# Altium schematic sheet CPU.SchDoc
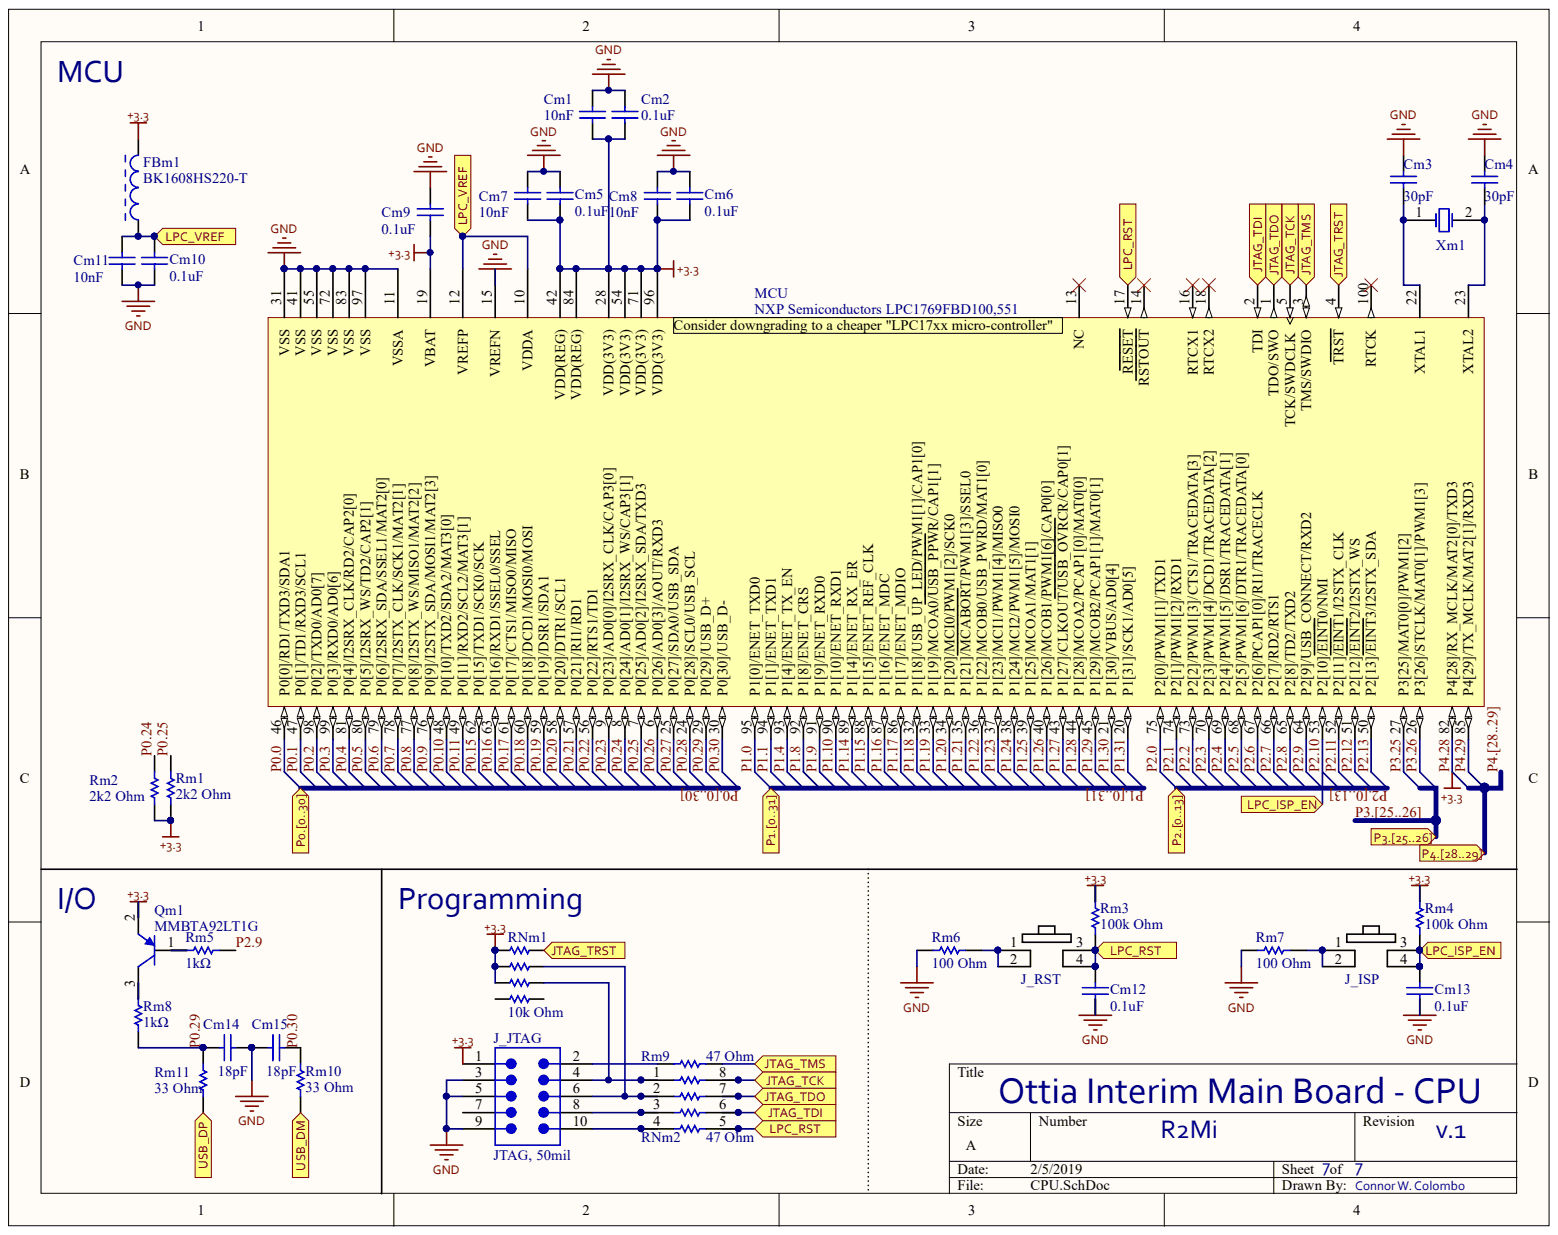
[diagram p0: CPU.SchDoc]

## Parts
Cm1  10nF  [C0402_B]
Cm2  0.1uF  [PCB-eedgklwdvp799dppbzpc-1]
Cm3  30pF  [PCB-8eq59f20arps2odoxr5p-1]
Cm4  30pF  [PCB-8eq59f20arps2odoxr5p-1]
Cm5  0.1uF  [PCB-eedgklwdvp799dppbzpc-1]
Cm6  0.1uF  [PCB-eedgklwdvp799dppbzpc-1]
Cm7  10nF  [C0402_B]
Cm8  10nF  [C0402_B]
Cm9  0.1uF  [PCB-eedgklwdvp799dppbzpc-1]
Cm10  0.1uF  [PCB-eedgklwdvp799dppbzpc-1]
Cm11  10nF  [C0402_B]
Cm12  0.1uF  [PCB-eedgklwdvp799dppbzpc-1]
Cm13  0.1uF  [PCB-eedgklwdvp799dppbzpc-1]
Cm14  18pF  [CAPC2013X88X50LL20T25]
Cm15  18pF  [CAPC2013X88X50LL20T25]
FBm1  BK1608HS220-T  [PCB-bv179vn443xkzl6mx6vj-1]
J_ISP  SYM-62e9a967b90dbd2e-1  [PCB-gaic1pl4705ifv3nefaq-1]  (value not drawn)
J_JTAG  JTAG, 50mil  [PCB-sv1um6pcy0j9gku5nhxe-1]
J_RST  SYM-62e9a967b90dbd2e-1  [PCB-gaic1pl4705ifv3nefaq-1]  (value not drawn)
MCU  NXP Semiconductors LPC1769FBD100,551  Manufacturer Part Number: LPC1769FBD100,551  [PCB-mc62e6spmbpfzkbif15a-1]
Qm1  MMBTA92LT1G  [ONSC-SOT-23-3-318-08_V]
Rm1  2k2 Ohm  [PCB-z7doepf12wtckttpzfig-1]
Rm2  2k2 Ohm  [PCB-z7doepf12wtckttpzfig-1]
Rm3  100k Ohm  [RESC1005X03L]
Rm4  100k Ohm  [RESC1005X03L]
Rm5  1kΩ  [PCB-prt6fc5zwjad3b6bgvrp-1]
Rm6  100 Ohm  [RESC1608X06N]
Rm7  100 Ohm  [RESC1608X06N]
Rm8  1kΩ  [PCB-prt6fc5zwjad3b6bgvrp-1]
Rm9  47 Ohm  [RESC1005X40X25LL05T10]
Rm10  33 Ohm  [PCB-9zfrujlo8x73edb5pce5-1]
Rm11  33 Ohm  [PCB-9zfrujlo8x73edb5pce5-1]
RNm1  10k Ohm  [PCB-jvpnorgmdmak109y2eet-1]
RNm2  47 Ohm  [PCB-wrffq4r8to75u59gxph1-1]
Xm1  Abracon ABLS-12.000MHZ-B2-T  [PCB-17bxwujfmayt9s0k9qiq-1]  (value not drawn)

## Ports
JTAG_TCK, JTAG_TDI, JTAG_TDO, JTAG_TMS, JTAG_TRST, LPC_ISP_EN, LPC_RST, LPC_VREF, P0.0, P0.1, P0.2, P0.3, P0.4, P0.5, P0.6, P0.7, P0.8, P0.9, P0.10, P0.11, P0.12, P0.13, P0.14, P0.15, P0.16, P0.17, P0.18, P0.19, P0.20, P0.21, P0.22, P0.23, P0.24, P0.25, P0.26, P0.27, P0.28, P0.29, P0.30, P0.[0..30], P1.0, P1.1, P1.2, P1.3, P1.4, P1.5, P1.6, P1.7, P1.8, P1.9, P1.10, P1.11, P1.12, P1.13, P1.14, P1.15, P1.16, P1.17, P1.18, P1.19, P1.20, P1.21, P1.22, P1.23, P1.24, P1.25, P1.26, P1.27, P1.28, P1.29, P1.30, P1.31, P1.[0..31], P2.0, P2.1, P2.2, P2.3, P2.4, P2.5, P2.6, P2.7, P2.8, P2.9, P2.10, P2.11, P2.12, P2.13, P2.[0..13], P3.25, P3.26, P3.[25..26], P4.28, P4.29, P4.[28..29], USB_DM, USB_DP

## Nets
GND: Cm1.1 Cm2.2 Cm3.2 Cm4.2 Cm5.2 Cm6.2 Cm7.1 Cm8.1 Cm9.2 Cm10.1 Cm11.2 Cm12.1 Cm13.1 Cm14.1 Cm15.2 J_JTAG.3 J_JTAG.5 J_JTAG.9 MCU.11(VSSA) MCU.15(VREFN) MCU.31(VSS) MCU.41(VSS) MCU.55(VSS) MCU.72(VSS) MCU.83(VSS) MCU.97(VSS) Rm6.1 Rm7.1
JTAG_TCK: MCU.5(TCK/SWDCLK) RNm2.8 ; port JTAG_TCK
JTAG_TDI: MCU.2(TDI) RNm2.6 ; port JTAG_TDI
JTAG_TDO: MCU.1(TDO/SWO) RNm2.7 ; port JTAG_TDO
JTAG_TMS: MCU.3(TMS/SWDIO) Rm9.2 ; port JTAG_TMS
JTAG_TRST: MCU.4(TRST) RNm1.4 ; port JTAG_TRST
LPC_RST: Cm12.2 J_RST.3 J_RST.4 MCU.17(RESET) Rm3.1 RNm2.5 ; port LPC_RST
LPC_VREF: Cm10.2 Cm11.1 FBm1.1 MCU.10(VDDA) MCU.12(VREFP) ; port LPC_VREF
P0.0: MCU.46(P0[0]/RD1/TXD3/SDA1) ; port P0.[0..30]  [also labelled P0.]
P0.1: MCU.47(P0[1]/TD1/RXD3/SCL1) ; port P0.[0..30]  [also labelled P0.]
P0.2: MCU.98(P0[2]/TXD0/AD0[7]) ; port P0.[0..30]  [also labelled P0.]
P0.3: MCU.99(P0[3]/RXD0/AD0[6]) ; port P0.[0..30]  [also labelled P0.]
P0.4: MCU.81(P0[4]/I2SRX_CLK/RD2/CAP2[0]) ; port P0.[0..30]  [also labelled P0.]
P0.5: MCU.80(P0[5]/I2SRX_WS/TD2/CAP2[1]) ; port P0.[0..30]  [also labelled P0.]
P0.6: MCU.79(P0[6]/I2SRX_SDA/SSEL1/MAT2[0]) ; port P0.[0..30]  [also labelled P0.]
P0.7: MCU.78(P0[7]/I2STX_CLK/SCK1/MAT2[1]) ; port P0.[0..30]  [also labelled P0.]
P0.8: MCU.77(P0[8]/I2STX_WS/MISO1/MAT2[2]) ; port P0.[0..30]  [also labelled P0.]
P0.9: MCU.76(P0[9]/I2STX_SDA/MOSI1/MAT2[3]) ; port P0.[0..30]  [also labelled P0.]
P0.10: MCU.48(P0[10]/TXD2/SDA2/MAT3[0]) ; port P0.[0..30]  [also labelled P0.]
P0.11: MCU.49(P0[11]/RXD2/SCL2/MAT3[1]) ; port P0.[0..30]  [also labelled P0.]
P0.15: MCU.62(P0[15]/TXD1/SCK0/SCK) ; port P0.[0..30]  [also labelled P0.]
P0.16: MCU.63(P0[16]/RXD1/SSEL0/SSEL) ; port P0.[0..30]  [also labelled P0.]
P0.17: MCU.61(P0[17]/CTS1/MISO0/MISO) ; port P0.[0..30]  [also labelled P0.]
P0.18: MCU.60(P0[18]/DCD1/MOSI0/MOSI) ; port P0.[0..30]  [also labelled P0.]
P0.19: MCU.59(P0[19]/DSR1/SDA1) ; port P0.[0..30]  [also labelled P0.]
P0.20: MCU.58(P0[20]/DTR1/SCL1) ; port P0.[0..30]  [also labelled P0.]
P0.21: MCU.57(P0[21]/RI1/RD1) ; port P0.[0..30]  [also labelled P0.]
P0.22: MCU.56(P0[22]/RTS1/TD1) ; port P0.[0..30]  [also labelled P0.]
P0.23: MCU.9(P0[23]/AD0[0]/I2SRX_CLK/CAP3[0]) ; port P0.[0..30]  [also labelled P0.]
P0.24: MCU.8(P0[24]/AD0[1]/I2SRX_WS/CAP3[1]) Rm2.2 ; port P0.[0..30]  [also labelled P0.]
P0.25: MCU.7(P0[25]/AD0[2]/I2SRX_SDA/TXD3) Rm1.2 ; port P0.[0..30]  [also labelled P0.]
P0.26: MCU.6(P0[26]/AD0[3]/AOUT/RXD3) ; port P0.[0..30]  [also labelled P0.]
P0.27: MCU.25(P0[27]/SDA0/USB_SDA) ; port P0.[0..30]  [also labelled P0.]
P0.28: MCU.24(P0[28]/SCL0/USB_SCL) ; port P0.[0..30]  [also labelled P0.]
P0.29: Cm14.2 MCU.29(P0[29]/USB_D+) Rm8.1 Rm11.2 ; port P0.[0..30]  [also labelled P0.]
P0.30: Cm15.1 MCU.30(P0[30]/USB_D-) Rm10.2 ; port P0.[0..30]  [also labelled P0.]
P1.0: MCU.95(P1[0]/ENET_TXD0) ; port P1.[0..31]  [also labelled P1.]
P1.1: MCU.94(P1[1]/ENET_TXD1) ; port P1.[0..31]  [also labelled P1.]
P1.4: MCU.93(P1[4]/ENET_TX_EN) ; port P1.[0..31]  [also labelled P1.]
P1.8: MCU.92(P1[8]/ENET_CRS) ; port P1.[0..31]  [also labelled P1.]
P1.9: MCU.91(P1[9]/ENET_RXD0) ; port P1.[0..31]  [also labelled P1.]
P1.10: MCU.90(P1[10]/ENET_RXD1) ; port P1.[0..31]  [also labelled P1.]
P1.14: MCU.89(P1[14]/ENET_RX_ER) ; port P1.[0..31]  [also labelled P1.]
P1.15: MCU.88(P1[15]/ENET_REF_CLK) ; port P1.[0..31]  [also labelled P1.]
P1.16: MCU.87(P1[16]/ENET_MDC) ; port P1.[0..31]  [also labelled P1.]
P1.17: MCU.86(P1[17]/ENET_MDIO) ; port P1.[0..31]  [also labelled P1.]
P1.18: MCU.32(P1[18]/USB_UP_LED/PWM1[1]/CAP1[0]) ; port P1.[0..31]  [also labelled P1.]
P1.19: MCU.33(P1[19]/MCOA0/USB_PPWR/CAP1[1]) ; port P1.[0..31]  [also labelled P1.]
P1.20: MCU.34(P1[20]/MCI0/PWM1[2]/SCK0) ; port P1.[0..31]  [also labelled P1.]
P1.21: MCU.35(P1[21]/MCABORT/PWM1[3]/SSEL0) ; port P1.[0..31]  [also labelled P1.]
P1.22: MCU.36(P1[22]/MCOB0/USB_PWRD/MAT1[0]) ; port P1.[0..31]  [also labelled P1.]
P1.23: MCU.37(P1[23]/MCI1/PWM1[4]/MISO0) ; port P1.[0..31]  [also labelled P1.]
P1.24: MCU.38(P1[24]/MCI2/PWM1[5]/MOSI0) ; port P1.[0..31]  [also labelled P1.]
P1.25: MCU.39(P1[25]/MCOA1/MAT1[1]) ; port P1.[0..31]  [also labelled P1.]
P1.26: MCU.40(P1[26]/MCOB1/PWM1[6]/CAP0[0]) ; port P1.[0..31]  [also labelled P1.]
P1.27: MCU.43(P1[27]/CLKOUT/USB_OVRCR/CAP0[1]) ; port P1.[0..31]  [also labelled P1.]
P1.28: MCU.44(P1[28]/MCOA2/PCAP1[0]/MAT0[0]) ; port P1.[0..31]  [also labelled P1.]
P1.29: MCU.45(P1[29]/MCOB2/PCAP1[1]/MAT0[1]) ; port P1.[0..31]  [also labelled P1.]
P1.30: MCU.21(P1[30]/VBUS/AD0[4]) ; port P1.[0..31]  [also labelled P1.]
P1.31: MCU.20(P1[31]/SCK1/AD0[5]) ; port P1.[0..31]  [also labelled P1.]
P2.0: MCU.75(P2[0]/PWM1[1]/TXD1) ; port P2.[0..13]  [also labelled P2.]
P2.1: MCU.74(P2[1]/PWM1[2]/RXD1) ; port P2.[0..13]  [also labelled P2.]
P2.2: MCU.73(P2[2]/PWM1[3]/CTS1/TRACEDATA[3]) ; port P2.[0..13]  [also labelled P2.]
P2.3: MCU.70(P2[3]/PWM1[4]/DCD1/TRACEDATA[2]) ; port P2.[0..13]  [also labelled P2.]
P2.4: MCU.69(P2[4]/PWM1[5]/DSR1/TRACEDATA[1]) ; port P2.[0..13]  [also labelled P2.]
P2.5: MCU.68(P2[5]/PWM1[6]/DTR1/TRACEDATA[0]) ; port P2.[0..13]  [also labelled P2.]
P2.6: MCU.67(P2[6]/PCAP1[0]/RI1/TRACECLK) ; port P2.[0..13]  [also labelled P2.]
P2.7: MCU.66(P2[7]/RD2/RTS1) ; port P2.[0..13]  [also labelled P2.]
P2.8: MCU.65(P2[8]/TD2/TXD2) ; port P2.[0..13]  [also labelled P2.]
P2.9: MCU.64(P2[9]/USB_CONNECT/RXD2) Rm5.2 ; port P2.[0..13]  [also labelled P2.]
P2.10: Cm13.2 J_ISP.3 J_ISP.4 MCU.53(P2[10]/EINT0/NMI) Rm4.1 ; port LPC_ISP_EN, port P2.[0..13]  [also labelled P2.]
P2.11: MCU.52(P2[11]/EINT1/I2STX_CLK) ; port P2.[0..13]  [also labelled P2.]
P2.12: MCU.51(P2[12]/EINT2/I2STX_WS) ; port P2.[0..13]  [also labelled P2.]
P2.13: MCU.50(P2[13]/EINT3/I2STX_SDA) ; port P2.[0..13]  [also labelled P2.]
P3.25: MCU.27(P3[25]/MAT0[0]/PWM1[2]) ; port P3.[25..26]  [also labelled P3.]
P3.26: MCU.26(P3[26]/STCLK/MAT0[1]/PWM1[3]) ; port P3.[25..26]  [also labelled P3.]
P4.28: Cm1.2 Cm2.1 Cm5.1 Cm6.1 Cm7.2 Cm8.2 Cm9.1 FBm1.2 J_JTAG.1 MCU.19(VBAT) MCU.28(VDD(3V3)) MCU.42(VDD(REG)) MCU.54(VDD(3V3)) MCU.71(VDD(3V3)) MCU.82(P4[28]/RX_MCLK/MAT2[0]/TXD3) MCU.84(VDD(REG)) MCU.96(VDD(3V3)) Qm1.2 Rm1.1 Rm2.1 Rm3.2 Rm4.2 RNm1.5 RNm1.6 RNm1.7 ; port P4.[28..29]  [also labelled P4.]
P4.29: MCU.85(P4[29]/TX_MCLK/MAT2[1]/RXD3) ; port P4.[28..29]  [also labelled P4.]
USB_DM: Rm10.1 ; port USB_DM
USB_DP: Rm11.1 ; port USB_DP
NetCm3_1: Cm3.1 MCU.22(XTAL1) Xm1.1
NetCm4_1: Cm4.1 MCU.23(XTAL2) Xm1.2
NetJ_ISP_1: J_ISP.1 J_ISP.2 Rm7.2
NetJ_JTAG_2: J_JTAG.2 Rm9.1
NetJ_JTAG_4: J_JTAG.4 RNm1.2 RNm2.1
NetJ_JTAG_6: J_JTAG.6 RNm1.3 RNm2.2
NetJ_JTAG_8: J_JTAG.8 RNm2.3
NetJ_JTAG_10: J_JTAG.10 RNm2.4
NetJ_RST_1: J_RST.1 J_RST.2 Rm6.2
NetQm1_1: Qm1.1 Rm5.1
NetQm1_3: Qm1.3 Rm8.2
P0.12: ; port P0.[0..30]  [also labelled P0.]
P0.13: ; port P0.[0..30]  [also labelled P0.]
P0.14: ; port P0.[0..30]  [also labelled P0.]
P1.2: ; port P1.[0..31]  [also labelled P1.]
P1.3: ; port P1.[0..31]  [also labelled P1.]
P1.5: ; port P1.[0..31]  [also labelled P1.]
P1.6: ; port P1.[0..31]  [also labelled P1.]
P1.7: ; port P1.[0..31]  [also labelled P1.]
P1.11: ; port P1.[0..31]  [also labelled P1.]
P1.12: ; port P1.[0..31]  [also labelled P1.]
P1.13: ; port P1.[0..31]  [also labelled P1.]

Not connected: J_JTAG 7; MCU 13(NC) 14(RSTOUT) 16(RTCX1) 18(RTCX2) 100(RTCK); RNm1 1 8

## Library data of the parts
Cm1 Cm7 Cm8 Cm11 = C1005X7S2A103K050BB: Eia Case Size: 0402, Voltage: 100, Cap Value: 10000, Tol: +/-10%, Thickness: 0.50 ± 0.05; Capacitance: 0.01 F; Capacitance Tolerance: ±10%; Dielectric Characteristic: X7S; Lead-Free Status: Lead Free; Voltage Rating (DC): 100.0 V; Case/Package: 0402; Component Kind: Standard; Library Name: Octopart library; Manufacturer Part Number: C1005X7S2A103K050BB; Number of Pins: 2; OctopartID: d1e3196219350e24; Octopart Url: http://octopart.com/c1005x7s2a103k050bb-tdk-25947568; Packaging: Cut Tape (CT); RoHS: Compliant; Seller Landing  Url: https://octopart-clicks.com/click/track?country=US&ak=8157f166&sig=0fecf54&sid=2402&ppid=25947568&vpid=88873635&ct=offers
Cm2 Cm5 Cm6 Cm9 Cm10 Cm12 Cm13 = CMP-48bd81bce6dc977a-1: NFM Series 0603 0.1 uF 2 A 16 V SMT Chip Capacitor Type EMI Filter; Manufacturer Part Number: NFM18PC104R1C3D; Capacitance: 100 nF; Current Rating: 2.00 A; Lead-Free Status: Lead Free; Shielding: No; Voltage Rating (DC): 16.0 V; Case/Package: 0603; Component Kind: Standard; Library Name: FavoritesLibrary; Mounting Style: Surface Mount; OctopartID: 48bd81bce6dc977a; Octopart URL: https://octopart.com/nfm18pc104r1c3d-murata-756487; Packaging: Cut Tape (CT); REACH SVHC Compliance: No SVHC; RoHS: Compliant; Size-Length: 1.60 mm; Size-Width: 800 µm
Cm3 Cm4 = CMP-69b3a034fa30b674-1: 0402 30 pF 50 V ±5 % Tolerance NP0 SMT Multilayer Ceramic Capacitor; Manufacturer Part Number: CC0402JRNPO9BN300; Capacitance: 30.0 pF; Capacitance Tolerance: ±5%; Dielectric Characteristic: C0G/NP0; Lead-Free Status: Lead Free; Temperature Coefficient: -30.0 ppm/°C to 30.0 ppm/°C; Voltage Rating (DC): 50.0 V; Case/Package: 0402; Component Kind: Standard; Library Name: FavoritesLibrary; Mounting Style: Surface Mount; Number of Pins: 2; OctopartID: 69b3a034fa30b674; Octopart URL: https://octopart.com/cc0402jrnpo9bn300-yageo-9287397; Operating Temperature: -55.0 °C to 125 °C; Packaging: Tape & Reel (TR); RoHS: Compliant
Cm14 Cm15 = C0805C180K5GACTU: Multilayer Ceramic Capacitors MLCC - SMD/SMT 50volts 18pF C0G 10%; Capacitance: 1.8e-11 F; Dielectric Characteristic: C0G/NP0; Lead-Free Status: Lead Free; Voltage Rating (DC): 50.0 V; Capacitance Tolerance: ±10%; Case/Package: 0805; Component Kind: Standard; Dielectric Material: Ceramic; Library Name: Octopart library; Manufacturer Part Number: C0805C180K5GACTU; Mounting Style: Surface Mount; OctopartID: b6d07fbc3fd26917; Octopart Url: https://octopart.com/c0805c180k5gactu-kemet-6728804; Packaging: Cut Tape (CT); RoHS: Compliant
FBm1 = CMP-2142e84d9b43fe85-1: Ind Chip Bead Shielded Multi-Layer 22Ohm 25% 100MHz Ferrite 1.5A 0603 T/R; Case/Package (SI): 1608; Current Rating: 1.50 A; Lead-Free Status: Lead Free; Resistance: 50.0 m?; Shielding: Yes; Case/Package: 0603; Component Kind: Standard; Frequency: 100 MHz; Halogen Free Status: Halogen Free; Library Name: FavoritesLibrary; Lifecycle Status: Active; Manufacturer Part Number: BK1608HS220-T; Mounting Style: Surface Mount; Number of Pins: 2; OctopartID: 2142e84d9b43fe85; Octopart URL: https://octopart.com/bk1608hs220-t-taiyo+yuden-368487; Operating Temperature: 125 °C (max); Packaging: Reel; RoHS: Compliant; Size-Height: 800 µm; Size-Length: 1.60 mm; Size-Width: 800 µm
J_ISP J_RST = 1825910-6: Switch Tactile OFF (ON) SPST Round Button PC Pins 0.05A 24VDC 100000Cycles 1.57N Thru-Hole Loose; ELV Compliant: Compliant; Component Kind: Standard; Digikey: 450-1650-ND; Library Name: Octopart library; OctopartID: 62e9a967b90dbd2e; Octopart URL: https://octopart.com/1825910-6-te+connectivity-42270338; Price: $0.10
J_JTAG = 20021121-00010C4LF: Conn Unshrouded Header HDR 10 POS 1.27mm Solder ST SMD T/R; Gender: Male; Number of Contacts: 10; Component Kind: Standard; Contact Material: Copper Alloy; Contact Plating: Gold; Library Name: Octopart library; Manufacturer Part Number: 20021121-00010C4LF; Mounting Style: Surface Mount, Vertical; OctopartID: 7911b4617bd7a6d6; Packaging: Reel; REACH SVHC Compliance: No SVHC; RoHS: Compliant
MCU = NXP Semiconductors LPC1769FBD100,551-1: LPC1769 Series 512 kB Flash 64 kB RAM 32-Bit SMT Microcontroller - LQFP-100; Clock Speed: 120 MHz; Lead-Free Status: Lead Free; Number of Bits: 32; RAM Memory Size: 65536 B; Supply Voltage (DC): 2.40 V (min); Component Kind: Standard; Library Name: FavoritesLibrary; Lifecycle Status: Active; Mounting Style: Surface Mount; Number of Pins: 100; OctopartID: d5c11c089c490a06; Octopart URL: http://octopart.com/lpc1769fbd100%2C551-nxp+semiconductors-12807406; REACH SVHC Compliance: No SVHC; RoHS: Compliant
Qm1 = MMBTA92LT1G: High Voltage Transistor, PNP Silicon, 3-Pin SOT-23, Pb-Free, Tape and Reel; Breakdown Voltage [Collector to Emitter]: 300.0 V; Current Rating: -0.5 A; Lead-Free Status: Lead Free; Power Dissipation: 0.3 W; Voltage Rating (DC): -300.0 V; Case/Package: SOT-23; Component Kind: Standard; Library Name: Octopart library; Lifecycle Status: Active; Manufacturer Part Number: MMBTA92LT1G; Mounting Style: Surface Mount; Number of Pins: 3; OctopartID: dd16141c4bc902d1; Octopart Url: https://octopart.com/mmbta92lt1g-on+semiconductor-46009; Packaging: Cut Tape (CT); REACH SVHC Compliance: No SVHC; RoHS: Compliant
Rm1 Rm2 = CMP-723cbba98d8eadfb-1: Res Thick Film 0603 2.2K Ohm 1% 1/10W ±100ppm/°C Molded SMD SMD Punched Carrier T/R; Manufacturer Part Number: ERJ-3EKF2201V; Case/Package (SI): 1608; Lead-Free Status: Lead Free; Power Rating: 100 mW; Resistance: 2.20 k?; Temperature Coefficient: -200 ppm/°C to 200 ppm/°C; Voltage Rating (DC): 75.0 V; Case/Package: 0603; Component Kind: Standard; Library Name: FavoritesLibrary; Lifecycle Status: Not Listed by Manufacturer; Mounting Style: Surface Mount; Number of Pins: 2; OctopartID: 723cbba98d8eadfb; Octopart URL: https://octopart.com/erj-3ekf2201v-panasonic-10476244; Operating Temperature: 155 °C (max); Packaging: Tape & Reel (TR), Cut Tape (CT); REACH SVHC Compliance: No SVHC; Resistance Tolerance: ±1%; RoHS: Compliant
Rm3 Rm4 = RC0402JR-07100KL: Res Thick Film 1.0 x 0.5 mm 100K Ohm 5% 0.063W(1/16W) 100ppm/ C Molded SMD Paper T/R; Component Kind: Standard; Library Name: Octopart library; Number of Pins: 2; Resistance Tolerance: ±5%; RoHS: Compliant; Size-Length: 1.00 mm
Rm5 Rm8 = ERJ-8GEYJ102V: Resistor, Chip Thick Film, 1 Kohm, 0.25wresistor, Chip Thick Film, 1 Kohm, 0.25w, 5%; Power Rating: 250 mW; Component Kind: Standard; Library Name: Octopart library; Lifecycle Status: Not Listed by Manufacturer; Manufacturer Part Number: ERJ-8GEYJ102V; Octopart URL: http://octopart.com/erj-8geyj102v-panasonic-55423814; Packaging: Tape & Reel (TR), Cut Tape (CT); REACH SVHC Compliance: No SVHC; Resistance Tolerance: ±5%
Rm6 Rm7 = ERJ-3EKF1000V: Res Thick Film 1.6 x 0.8 mm 100 Ohm 1% 0.1W(1/10W) 100ppm/ C Molded SMD Punched Carrier T/R; Case/Package (SI): 1608; Resistance: 100 Ω; Voltage Rating (DC): 75.0 V; Case/Package: 0603; Component Kind: Standard; Library Name: Octopart library; Lifecycle Status: Not Listed by Manufacturer; Manufacturer Part Number: ERJ-3EKF1000V; Mounting Style: Surface Mount; Packaging: Tape & Reel (TR), Cut Tape (CT); Resistance Tolerance: ±1%; RoHS: Compliant; Size-Height: 450 µm; Size-Width: 800 µm
Rm9 = RC0402JR-0747RL: YAGEO (PHYCOMP)   RC0402JR-0747RL   RESISTOR, RC31 0402 47R; Lead-Free Status: Lead Free; Power Rating: 0.0625 W; Resistance: 47.0 Ω; Resistance Tolerance: ±5%; Voltage Rating (DC): 50.0 V; Case/Package: 0402; Component Kind: Standard; Library Name: Octopart library; Manufacturer Part Number: RC0402JR-0747RL; Mounting Style: Surface Mount; Number of Pins: 2; OctopartID: 921c596654e74ddb; Octopart Url: https://octopart.com/rc0402jr-0747rl-yageo-40952733; REACH SVHC Compliance: No SVHC; RoHS: Compliant; Size-Height: 0.00035 m; Size-Length: 0.001 m; Size-Width: 0.0005 m
Rm10 Rm11 = CMP-104ed9c8e86ecf89-1: Res Thick Film 0603 33 Ohm 1% 1/10W ±100ppm/°C Molded SMD SMD Punched Carrier T/R; Manufacturer Part Number: ERJ-3EKF33R0V; Case/Package (SI): 1608; Lead-Free Status: Lead Free; Power Rating: 100 mW; Resistance: 33.0 ?; Temperature Coefficient: -200 ppm/°C to 200 ppm/°C; Voltage Rating (DC): 75.0 V; Case/Package: 0603; Component Kind: Standard; Library Name: FavoritesLibrary; Lifecycle Status: Not Listed by Manufacturer; Mounting Style: Surface Mount; Number of Pins: 2; OctopartID: 104ed9c8e86ecf89; Octopart URL: https://octopart.com/erj-3ekf33r0v-panasonic-9231686; Packaging: Tape & Reel (TR), Cut Tape (CT); REACH SVHC Compliance: No SVHC; Resistance Tolerance: ±1%; RoHS: Compliant
RNm1 = YC164-JR-0710KL: Res Thick Film Array 10K Ohm 5% ???}200ppm/??? ISOL Molded 8-Pin 1206(4 X 0603) Convex SMD Paper T/R; Voltage Rating (AC): 50.0 V; Component Kind: Standard; Library Name: Octopart library; Manufacturer Part Number: YC164-JR-0710KL; Mounting Style: Surface Mount; Packaging: Tape & Reel; Resistance Tolerance: ±5%; Voltage Rating (DC): 50.0 V
RNm2 = CMP-9def57a87d214228-1: Res Thick Film Array 47 Ohm 5% 200ppm/ C ISOL Molded 8-Pin 0804(4 X 0402) Convex SMD Punched Carrier T/R; Manufacturer Part Number: EXB-28V470JX; Lead-Free Status: Lead Free; Number of Contacts: 8; Resistance: 47.0 ?; Temperature Coefficient: -200 ppm/°C to 200 ppm/°C; Voltage Rating (DC): 50.0 V; Case/Package: 0402; Component Kind: Standard; Library Name: FavoritesLibrary; Lifecycle Status: Not Listed by Manufacturer; Mounting Style: Surface Mount; Number of Pins: 8; OctopartID: 9def57a87d214228; Octopart URL: https://octopart.com/exb-28v470jx-panasonic-55428520; Packaging: Tape & Reel (TR), Cut Tape (CT); REACH SVHC Compliance: No SVHC; Resistance Tolerance: ±5%; RoHS: Compliant; Size-Height: 350 µm; Size-Length: 2.00 mm; Size-Thickness: 350 µm; Size-Width: 1.00 mm
Xm1 = ABLS-12.000MHZ-B2-T: Crystal 12MHz ??20ppm (Tol) ??50ppm (Stability) 18pF FUND 50Ohm Automotive 2-Pin HC-49/US SMD T/R; Frequency: 12.0 MHz; Lead-Free Status: Lead Free; Load Capacitance: 18.0 pF; Case/Package: HC-49; Component Kind: Standard; Library Name: Octopart library; Manufacturer: Abracon; Manufacturer Part Number: ABLS-12.000MHZ-B2-T; Mounting Style: Surface Mount; Number of Pins: 2; OctopartID: 3dd8f4d2cb48e21f; Operating Temperature: -20.0 °C to 70.0 °C; REACH SVHC Compliance: Contains SVHC; Size-Height: 4.20 mm; Size-Length: 12.7 mm
